# Altium schematic sheet Power.SchDoc(Power)
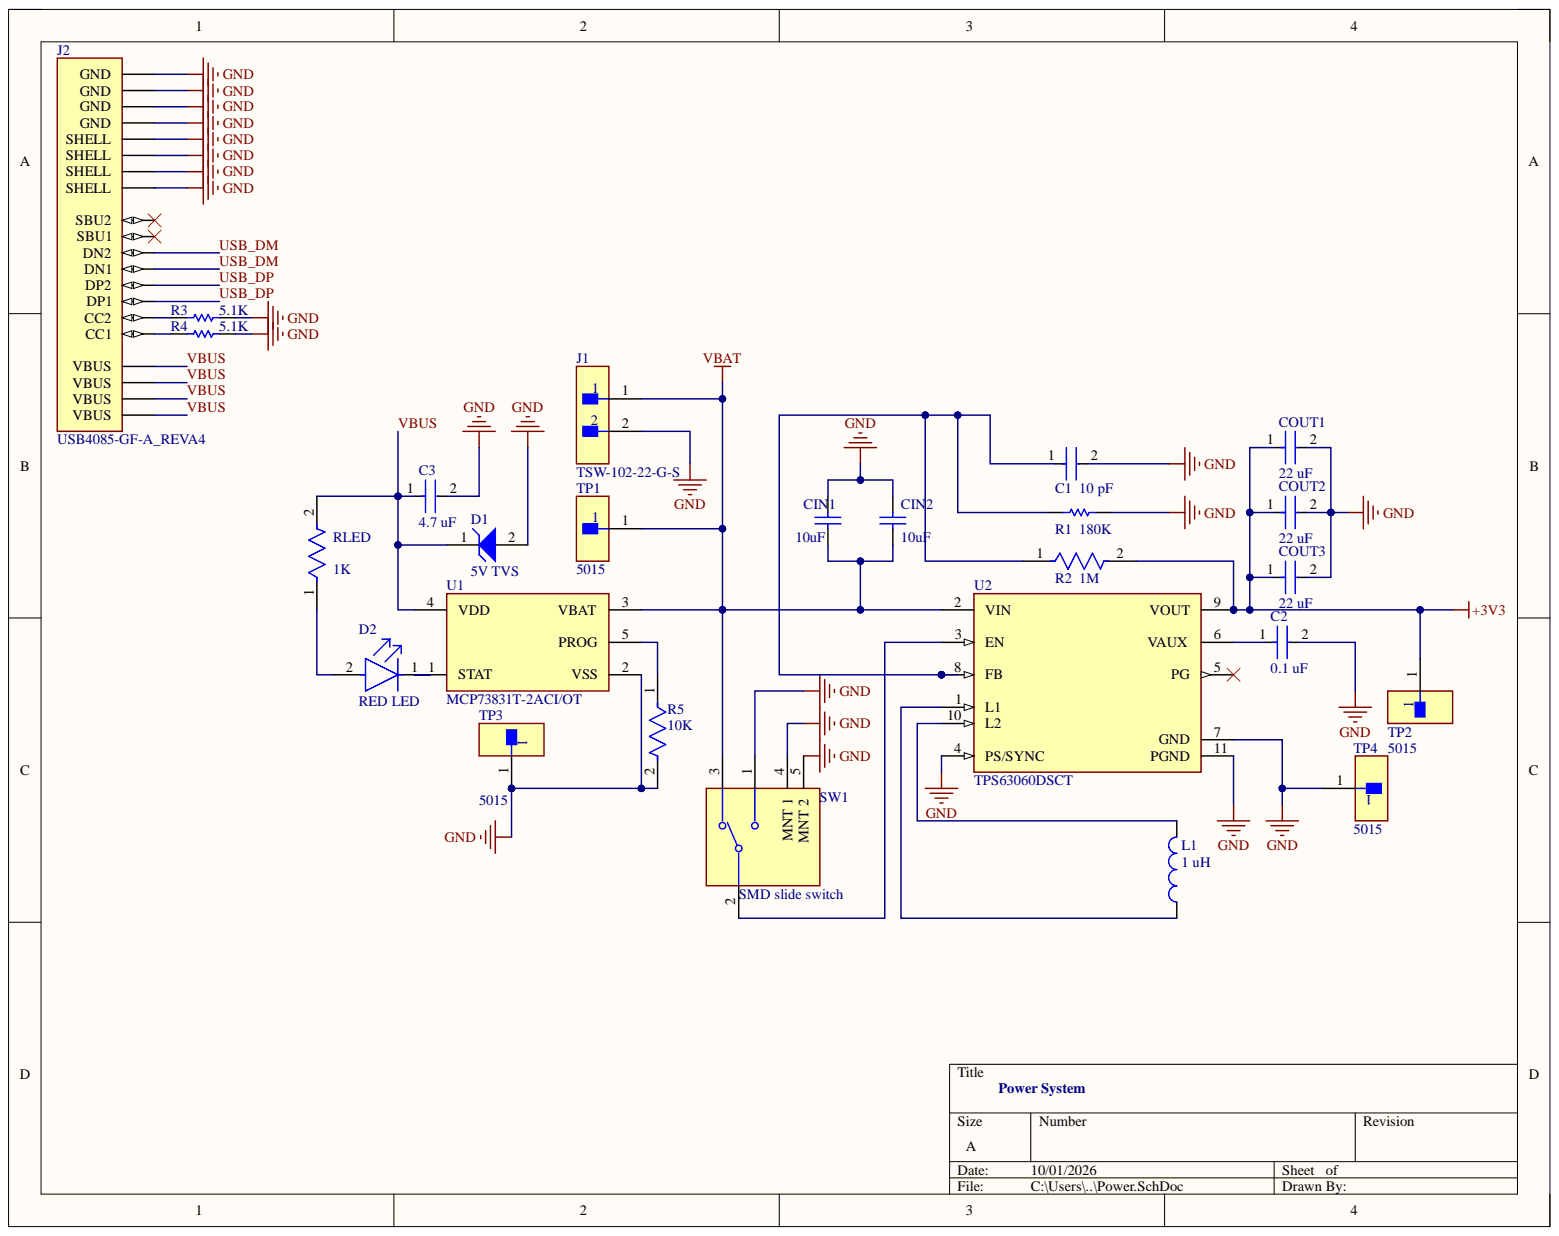
[diagram p0: Power.SchDoc]

## Parts
C1  10 pF  [FP-C0402-BB-MFG]
C2  0.1 uF  [FP-CC0402-MFG]
C3  4.7 uF  [FP-GRM21B-0_15-IPC_A]
CIN1  10uF  [CAPC2013X135X50ML10T25]
CIN2  10uF  [CAPC2013X135X50ML10T25]
COUT1  22 uF  [FP-0805-L_2_0_0_2-W_1_25-IPC_A]
COUT2  22 uF  [FP-0805-L_2_0_0_2-W_1_25-IPC_A]
COUT3  22 uF  [FP-0805-L_2_0_0_2-W_1_25-IPC_A]
D1  5V TVS  [ONSC-SOD-323-2-477-02_G_V]
D2  RED LED  [FP-SML-LX0603IW-TR-MFG]
J1  TSW-102-22-G-S  [FP-TSW-102-22-G-S-MFG]  (no BOM)
J2  USB4085-GF-A_REVA4  [GCT_USB4085-GF-A_REVA4]
L1  1 uH  [COIL-XFL4020_V]
R1  180K  [RESC1005X40X25NL10T10]
R2  1M  [FP-AF0402-IPC_A]
R3  5.1K  [RESC1005X40X25NL05T10]
R4  5.1K  [RESC1005X40X25NL05T10]
R5  10K  [FP-SR0402-MFG]
RLED  1K  [FP-AF0402-IPC_B]
SW1  SMD slide switch  [FP-JS102000SAQN-MFG]
TP1  5015  [FP-5015-MFG]  (no BOM)
TP2  5015  [FP-5015-MFG]  (no BOM)
TP3  5015  [FP-5015-MFG]  (no BOM)
TP4  5015  [FP-5015-MFG]  (no BOM)
U1  MCP73831T-2ACI/OT  [FP-C04-091-OT-IPC_B]
U2  TPS63060DSCT  [FP-DSC0010E-MFG]

## Nets
+3V3: COUT1.1 COUT2.1 COUT3.1 R2.2 TP2.1 U2.9(VOUT)
GND: C1.2 C2.2 C3.2 CIN1.2 CIN2.2 COUT1.2 COUT2.2 COUT3.2 D1.2 J1.2 J2.A1(GND) J2.A12(GND) J2.B1(GND) J2.B12(GND) J2.S1(SHELL) J2.S2(SHELL) J2.S3(SHELL) J2.S4(SHELL) R1.2 R3.2 R4.2 R5.2 SW1.1 SW1.4(MNT_1) SW1.5(MNT_2) TP3.1 TP4.1 U1.2(VSS) U2.4(PS/SYNC) U2.7(GND) U2.11(PGND)
VBAT: CIN1.1 CIN2.1 J1.1 SW1.3 TP1.1 U1.3(VBAT) U2.2(VIN)
USB_DM: J2.A7(DN1) J2.B7(DN2)
USB_DP: J2.A6(DP1) J2.B6(DP2)
VBUS: C3.1 D1.1 J2.A4(VBUS) J2.A9(VBUS) J2.B4(VBUS) J2.B9(VBUS) RLED.2 U1.4(VDD)
NetC1_1: C1.1 R1.1 R2.1 U2.8(FB)
NetC2_1: C2.1 U2.6(VAUX)
NetD2_1: D2.1 U1.1(STAT)
NetD2_2: D2.2 RLED.1
NetJ2_A5: J2.A5(CC1) R4.1
NetJ2_B5: J2.B5(CC2) R3.1
NetL1_1: L1.1 U2.1(L1)
NetL1_2: L1.2 U2.10(L2)
NetR5_1: R5.1 U1.5(PROG)
NetSW1_2: SW1.2 U2.3(EN)

Not connected: J2 A8(SBU1) B8(SBU2); U2 5(PG)

## Library data of the parts
C1 = CMP-2008-03045-2: CAP CER 10PF 50V NP0 0402; Manufacturer: Kemet; Manufacturer Part Number: C0402C100C5GACTU; Material: Ceramic; Temperature Characteristic: C0G; Temperature Coefficient: 15%; Tolerance: 0.25 pF; Automotive: No; Capacitance: 10 pF; Category: Cap; Component Kind: Standard; ComponentLink2Description: Datasheet; ComponentLink2URL: https://content.kemet.com/datasheets/KEM_C1002_X7R_SMD.pdf; Device Class L1: Passive Components; Device Class L2: Capacitors; Device Class L3: Ceramic Capacitors; Digikey Description: CAP CER 10PF 50V NP0 0402; Height: 0.55mm; Ipc Land Pattern Name: CAPC10050X50; Lead Free: Yes; Library Name: Altium Content Vault; Mouser Description: Multilayer Ceramic Capacitors MLCC - SMD/SMT 50volts 10pF C0G C0G +/-0.25pF; Octopart: https://octopart.com/c0402c100c5gactu-kemet-125456; Package: C0402-BB; RoHS: TRUE; Temperature: -55°C to +125°C; Temperature Range High: +125°C; Temperature Range Low: -55°C; Value: 10 pF; Voltage Rating: 50 V
C2 = CMP-03422-001312-1: CAP CER 0.1UF 16V X5R 0402; Manufacturer: YAGEO; Manufacturer Part Number: CC0402MRX5R7BB104; Automotive Grade: NA; Capacitance: 100 nF; Material: Ceramic; Temperature Coefficient: 30ppm/°C; Tolerance: 0.2; Value: 100 nF; Automotive: No; Category: Cap; Component Kind: Standard; ComponentLink2Description: Datasheet; ComponentLink2URL: http://www.yageo.com/documents/recent/UPY-GP_NP0_16V-to-50V_16.pdf; Device Class L1: Passive Components; Device Class L2: Capacitors; Device Class L3: Ceramic Capacitors; Digikey Description: CAP CER 0.1UF 16V X5R 0402; Footprint Url: http://www.yageo.com/exep/pages/download/literatures/UPY-C_GEN_24.pdf; Height: 0.55mm; Ipc Land Pattern Name: CAPC10050X50; Lead Free: Yes; Library Name: Altium Content Vault; Mouser Description: Multilayer Ceramic Capacitors MLCC - SMD/SMT 100nF 16V X5R 20%; Octopart: https://octopart.com/cc0402mrx5r7bb104-yageo-33242280; Package: CC0402; RoHS: TRUE; Temperature: -55°C to +125°C; Temperature Characteristic: X5R; Temperature Range High: +125°C; Temperature Range Low: -55°C; Voltage Rating: 16 V
C3 = CMP-06035-033280-1: Chip Multilayer Ceramic Capacitors for General Purpose, 0805, 4.7uF, X6S, 22%, 20%, 35V; Capacitance: 4.7uF; Case/Package: 0805; Component Kind: Standard; Dielectric: X6S; Length: 2mm; Library Name: Altium Content Vault; Thickness: 1.25mm; Tolerance: 20%; Voltage Rating (DC): 35V; Width: 1.25mm
CIN1 CIN2 = CMP-2000-05449-1: Chip Capacitor, 10 uF, +/- 10%, 16 V, -25 to 85 degC, 0805 (2012 Metric), RoHS, Tape and Reel; Manufacturer: Taiyo Yuden; PCN Part Number: X5R,X7RDielectrics23/Jan/2008; Capacitance: 10µF; Catalog Drawings: xMKSeries_0805_1.25; Kits: 587-1797-KIT-ND-KITCAPCERHICAP0603&0805S; Online Catalog: MSeries; Other Names: 587-1295-1; Temperature Coefficient: X5R; Voltage - Rated: 16V; Applications: GeneralPurpose; Category: Capacitors; Component Kind: Standard; Datasheet URL: https://datasheet.ciiva.com/26871/getdatasheetpartid-638258-26871376.pdf; Datasheet Version: Sep-12; Family: CeramicCapacitors; Features: -; Height - Seated (Max): -; Lead Spacing: -; Lead Style: -; Library Name: Altium Content Vault; Manufacturer URL: http://www.t-yuden.com/; Mounting Type: SurfaceMount,MLCC; Operating Temperature: -55degC~85degC; Package / Case: 0805(2012Metric); Package Description: 2-Pin Surface Mount Device, Body 2 x 1.25 mm; Package Reference: 805; Packaging: CutTape(CT); Ratings: -; Series: M; Size / Dimension: 0.079"Lx0.049"W(2.00mmx1.25mm); Standard Package: 1; Thickness (Max): 0.053"(1.35mm); Tolerance: ±10%
COUT1-COUT3 = CMP-14477-000140-3: Multilayer Ceramic Capacitor 22uF 16V X5R 20% SMD 0805 T/R; Capacitance: 22uF; Case Code (Imperial): 0805; Case Code (Metric): 2012; Case/Package: 0805; Component Kind: Standard; Construction: Flat; Dielectric: X5R; Halogen Free: Halogen Free; Height: 1.25mm; Length: 2mm; Library Name: Altium Content Vault; Max Operating Temperature: 85°C; Min Operating Temperature: -55°C; Mount: Surface Mount; Package Quantity: 1; Packaging: Tape and Reel; Resistor Type: Ceramic; RoHS Compliant: Yes; Series: M; Termination: SMD/SMT; Thickness: 0.049inch; Tolerance: 20%; Voltage Rating: 16V; Voltage Rating (DC): 16V; Width: 0.039inch
D1 = CMP-1061-00632-1: Transient Voltage Suppressor Diode, for ESD Protection, 2-Pin SOD-323, Pb-Free, Tape and Reel; Manufacturer: On Semiconductor; PartNumber: SD05T3G; Direction: Unidirectional; IR Max (uA): 10; PPK Max (W): 350; Component Kind: Standard; ComponentLink1Description: Manufacturer URL; ComponentLink1URL: http://www.onsemi.com/; ComponentLink2Description: Datasheet; ComponentLink2URL: http://www.onsemi.com/pub_link/Collateral/SD05T1-D.PDF; ComponentLink3Description: Package Specification; ComponentLink3URL: http://www.onsemi.com/pub_link/Collateral/SD05T1-D.PDF; DatasheetVersion: Rev. 2; Library Name: Altium Content Vault; Mounting Technology: Surface Mount; PackageDescription: 2-Pin SOD, Body 1.7 x 1.25 mm; PackageReference: SOD-323-2-477-02_G; PackageVersion: Rev. G, 02/2005; Packing: Tape and Reel; RoHS: Pb-Free
D2 = CMP-57192-000012-1: LED RED DIFFUSED SMD; Approvals: UL; Dominant Wavelength: 635nm; Forward Current: 20mA; Forward Voltage: 2V; Lens Color: White; Luminous Intensity: 14mcd; Number of LEDs: 1; Power Dissipation: 50mW; Viewing Angle: 160°; Case Code (Imperial): 0603; Case Code (Metric): 1608; Case/Package: 0603; Color: Red; Component Kind: Standard; Diameter: 0.061inch; Height: 0.6mm; Illumination Color: Red; Lead Free: Lead Free; Length: 1.6mm; Lens Style: Diffused; Lens Transparency: Diffused; Library Name: Altium Content Vault; Max Operating Temperature: 85°C; Min Operating Temperature: -25°C; Mount: Surface Mount; Number of Pins: 2; Package Quantity: 1; Packaging: Tape and Reel; RoHS Compliant: Yes; Test Current: 20mA; Wavelength: 635nm; Width: 0.8mm
J1 = CMP-02766-000117-1: CONN HEADER VERT 2POS 2.54MM; Gender: Male; Max Current Rating: 7.6A; Max Voltage Rating (AC): 550V; Number of Contacts: 2; Contact Material: Bronze; Contact Plating: Gold; Depth: 2.54mm; Housing Color: Black; Housing Material: Polyester; Length: 5.08mm; Library Name: Altium Content Vault; Max Operating Temperature: 125°C; Min Operating Temperature: -55°C; Number of Rows: 1; Orientation: Straight; Packaging: Bulk; Pitch: 2.54mm; Radiation Hardening: No; REACH SVHC: No SVHC; Termination: Solder
J2 = CMP-002-00071-1: USB-C (USB TYPE-C) USB 2.0 Receptacle Connector 24 (16+8 Dummy) Position Through Hole, Right Angle, low cost, 3.46mm profile, 16 pin, horizontal, Top mount; MF: Global Connector Technology; Availability: In Stock; Case/Package: None; Check_prices: https://www.snapeda.com/parts/USB4085-GF-A/Global+Connector+Technology/view-part/?ref=eda; Component Kind: Standard; Component Type: Standard; Design Item ID: USB4085-GF-A_REVA4; MP: USB4085-GF-A; Pins: 20; Price: None; Purchase-URL: https://pricing.snapeda.com/search/part/USB4085-GF-A/?ref=eda; SnapEDA_Link: https://www.snapeda.com/parts/USB4085-GF-A/Global+Connector+Technology/view-part/?ref=snap; Source: USB4085-GF-A_REVA4.SchLib; Library Name: AI-Glasses
L1 = CMP-2000-07423-1: Shielded Power Inductor, 1 uH, 64 MHz, -40 to 125 degC, 2-Pin SMD, RoHS, Tape and Reel; Manufacturer: Coilcraft; Case Height: 2.1mm; Case Length: 4.3mm; Core Material: Composite; Inductance: 1uH; Maximum DC Current: 11A; Maximum DC Resistance: 11.9mOhms; Self Resonant Frequency: 64MHz; Test Frequency: 100kHz; Tolerance: 20%; Case Width: 4.3mm; Component Kind: Standard; Datasheet URL: http://www.mouser.com/ds/2/597/xfl4020-270756.pdf; Datasheet Version: 07/2012; Dimensions: 4.3mmWx4.3mmLx2.1mmH; Library Name: Altium Content Vault; Manufacturer URL: http://www.coilcraft.com/; Mounting Technology: SurfaceMount; Operating Temperature Range: -40Cto+125C; Package Description: 2-Pin Surface Mount Device, Body 4 x 4 mm, Pitch 2.37 mm; Package Reference: XFL4020; Packaging: Reel; Product: PowerInductors; RoHS: Details; Series: XFL4020; Shielding: Shielded; Termination Style: SMD/SMT
R1 = CMP-2002-05699-1: Manufacturer: TE Connectivity / AMP; Part Number: CRG0402F180K; Component Kind: Standard; Library Name: Altium Content Vault
R2 = CMP-03412-044504-1: Anti-Sulfurated Chip Resistors Automotive Grade 1MΩ 1% 100ppm/°C 0402; Max Power Dissipation: 63mW; Resistance: 1MR; Case/Package: 0402; Component Kind: Standard; Features: Moisture Resistant; Height: 0.015inch; Length: 0.039inch; Library Name: Altium Content Vault; Max Operating Temperature: 155°C; Min Operating Temperature: -55°C; Number of Terminations: 2; Packaging: Tape and Reel; Resistor Type: Thick Film; RoHS Compliant: Yes; Series: AF; Temperature Coefficient: 100ppm/°C; Tolerance: 1%; Width: 0.02inch
R3 R4 = CMP-2002-07753-1: Manufacturer: Yageo / Phycomp; Part Number: RC0402FR-075K1L; Component Kind: Standard; Library Name: Altium Content Vault
R5 = CMP-03412-025841-1: Surge Chip Resistor Automotive Grade Thick Film 0402 10kOhm 1% Paper T/R; Manufacturer: Yageo; Manufacturer Part Number: SR0402FR-7T10KL; Automotive Grade: Grade 0; Power Rating: 0.2W; Resistance: 10KΩ; Tolerance: 0.01; Value: 10KΩ; Voltage Rating: 50V; Automotive: Yes; Category: Res; Component Kind: Standard; ComponentLink2Description: Datasheet; ComponentLink2URL: http://www.yageo.com/documents/recent/PYu-SR_D_F%20tol_RoHS_L_3.pdf; Composition: Thick Film; Device Class L1: Passive Components; Device Class L2: Resistors; Device Class L3: Chip SMD Resistors; Digikey Description: Surge Chip Resistor Automotive Grade Thick Film 0402 10kOhm 1% Paper T/R; Footprint Url: http://www.yageo.com/exep/pages/download/literatures/PYu-R_Mount_10.pdf; Height: 0.4mm; Ipc Land Pattern Name: RESC10050X35; Lead Free: Yes; Library Name: Altium Content Vault; Octopart: https://octopart.com/sr0402fr-7t10kl-yageo-91478061; Package: SR0402; RoHS: TRUE; Series: SR; Temperature: -55°C to +155°C; Temperature Range High: +155°C; Temperature Range Low: -55°C
RLED = CMP-03412-038335-1: Anti-Sulfurated Chip Resistors Automotive Grade 1kΩ 1% 100ppm/°C 0402; Max Power Dissipation: 63mW; Resistance: 1kR; Case/Package: 0402; Component Kind: Standard; Features: Moisture Resistant; Height: 0.015inch; Length: 0.039inch; Library Name: Altium Content Vault; Max Operating Temperature: 155°C; Min Operating Temperature: -55°C; Number of Terminations: 2; Packaging: Tape and Reel; Resistor Type: Thick Film; RoHS Compliant: Yes; Series: AF; Temperature Coefficient: 100ppm/°C; Tolerance: 1%; Width: 0.02inch
SW1 = CMP-10038-000025-1: SWITCH SLIDE SPDT 1MA 5V; Actuator Color: Black; Actuator Length: 1.5mm; Actuator Material: Nylon; Actuator Type: Standard; Contact Resistance: 70mR; Electrical Life: 15000Cycles; Switch Function: On-On; Case/Package: SMD/SMT; Component Kind: Standard; Contact Current Rating: 1mA; Contact Material: Copper Alloy; Contact Plating: Silver; Current Rating: 1mA; Depth: 4.82mm; Flammability Rating: UL94 V-2; Housing Material: Nylon; Length: 7.8mm; Library Name: Altium Content Vault; Max Current Rating: 1mA; Max Operating Temperature: 85°C; Max Voltage Rating (DC): 5V; Min Operating Temperature: -20°C; Mount: Surface Mount; Orientation: Right Angle; Package Quantity: 1; Packaging: Tape and Reel; Radiation Hardening: No; REACH SVHC: No SVHC; RoHS Compliant: Yes; Series: JS; Switch Travel: 1.5mm; Termination: Gull Wing; Throw Configuration: SPDT; Voltage Rating (DC): 5V
TP1-TP4 = CMP-2000-05183-2: PC TEST POINT MINIATURE; Manufacturer: keystone; Conn Orientation: Straight; Number Of Contacts: 1; Automotive: No; Category: Conn; ComponentLink2Description: Datasheet; ComponentLink2URL: http://www.keyelco.com/product-pdf.cfm?p=1353; Contact Material: Silver; Device Class L1: Connectors; Device Class L2: unset; Device Class L3: unset; Digikey Description: PC TEST POINT MINIATURE; Height: 1.65mm; Lead Free: Yes; Library Name: Altium Content Vault; Manufacturer Part Number: 5015; Octopart: https://octopart.com/5015-keystone-3822; Package: 5015; RoHS: TRUE
U1 = CMP-0133-00143-2: IC CONTROLLR LI-ION 4.2V SOT23-5; Drain to Source Resistance: 350mR; Max Charge Current: 500mA; Max Input Voltage: 6V; Max Output Voltage: 4.5V; Max Power Dissipation: 1.54W; Min Output Voltage: 4.168V; Min Supply Voltage: 3.75V; Number of Cells: 1; Voltage Rating: 4.534V; Case/Package: SOT-23; Component Kind: Standard; Contact Plating: Tin; Height: 0.051inch; Lead Free: Lead Free; Length: 0.122inch; Library Name: Altium Content Vault; Max Operating Temperature: 85°C; Max Supply Voltage: 6V; Min Operating Temperature: -40°C; Mount: Surface Mount; Number of Channels: 1; Number of Outputs: 1; Number of Pins: 5; Output Current: 500mA; Output Voltage: 4.2V; Packaging: Tape and Reel; Radiation Hardening: No; REACH SVHC: No SVHC; Termination: SMD/SMT; Weight: 0.00709oz; Width: 0.071inch
U2 = CMP-04918-000152-1: IC REG BCK BST ADJ 2A 10WSON; Efficiency: 93%; Max Input Voltage: 12V; Max Output Current: 1A; Max Output Voltage: 8V; Min Input Voltage: 2.5V; Number of Outputs: 1; Output Type: Adjustable; Quiescent Current: 30uA; Switching Frequency: 2.4MHz; Synchronous Rectifier: Yes; Topology: Buck-Boost; Component Kind: Standard; Contact Plating: Gold; Height: 0.75mm; Lead Free: Lead Free; Length: 3.15mm; Library Name: Altium Content Vault; Max Operating Temperature: 85°C; Min Operating Temperature: -40°C; Min Output Voltage: 2.5V; Mount: Surface Mount; Number of Pins: 10; Operating Supply Voltage: 12V; Output Current: 1A; Output Voltage: 8V; Package Quantity: 250; Packaging: Tape and Reel; Radiation Hardening: No; REACH SVHC: No SVHC; Width: 3.15mm
